# Altium schematic sheet F407_MCU.SchDoc(F407_MCU)
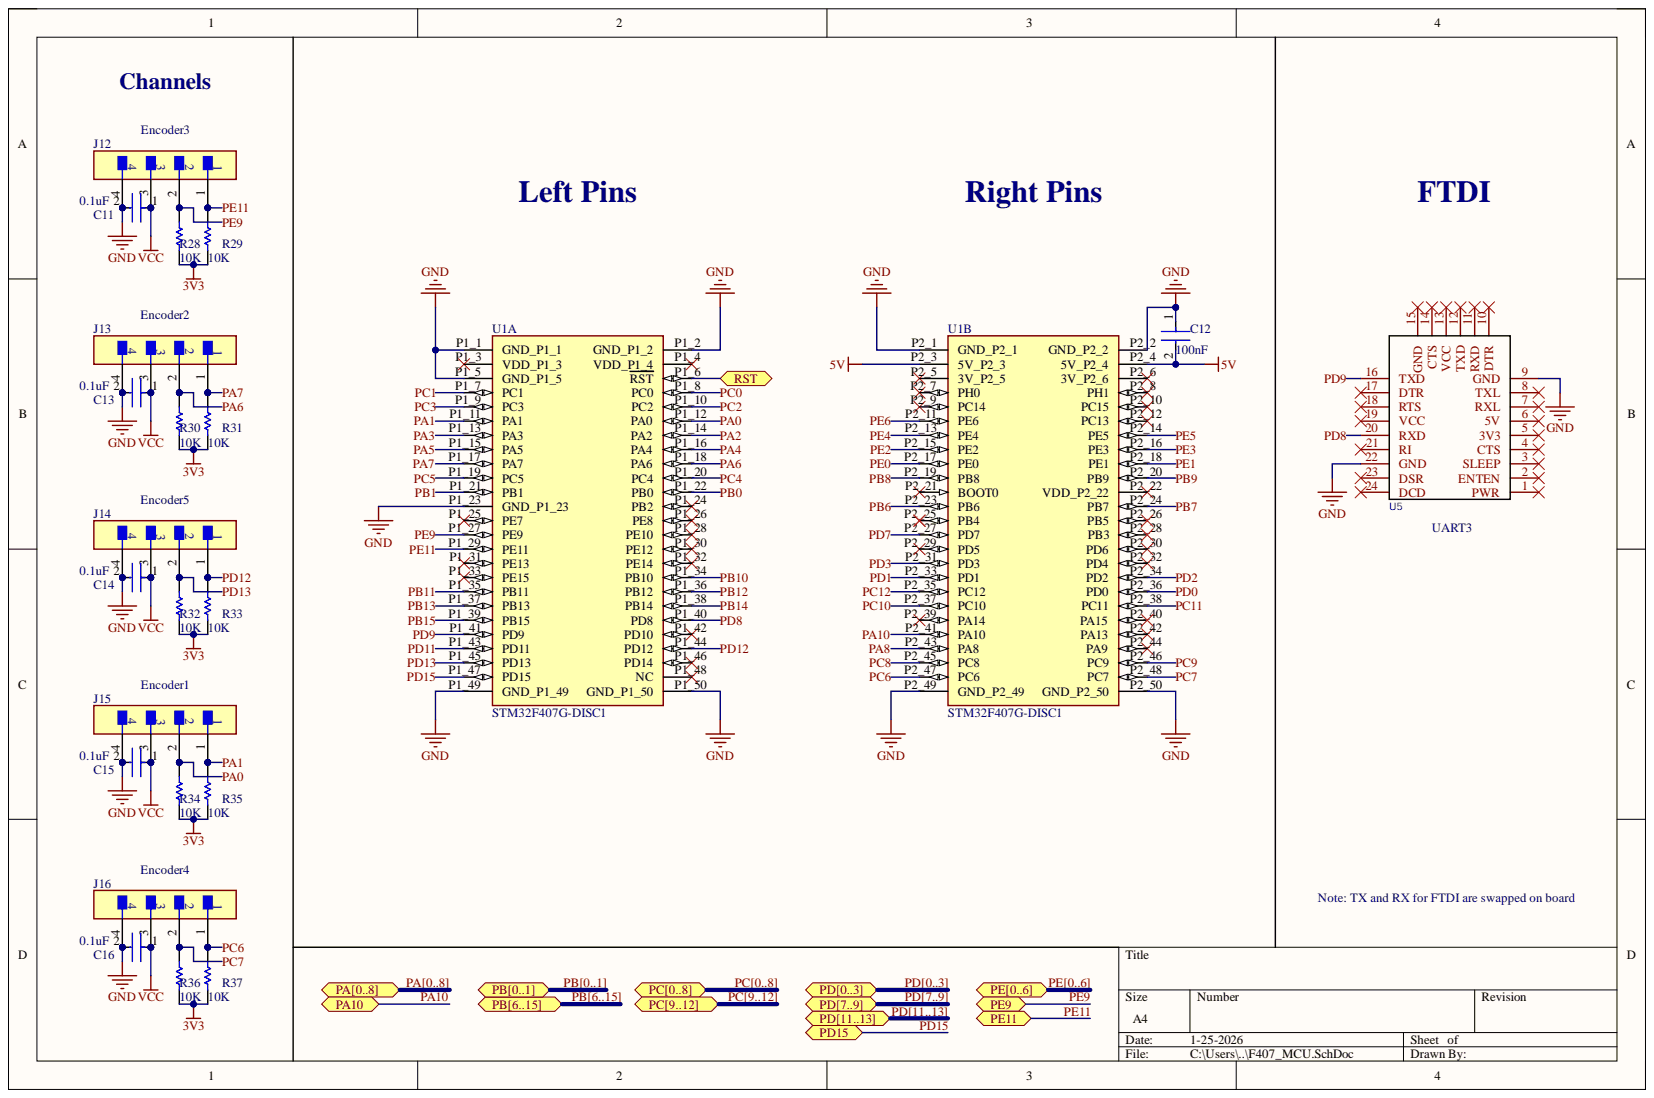
[diagram p0: F407_MCU.SchDoc]

## Parts
C11  CC0805KRX7R9BB104  [FP-CC0805-0_85-MFG]  (value not drawn)
C12  value 100 nF  [FP-CC0805-0_85-MFG]  (hidden comment: CC0805KRX7R9BB104)
C13  CC0805KRX7R9BB104  [FP-CC0805-0_85-MFG]  (value not drawn)
C14  CC0805KRX7R9BB104  [FP-CC0805-0_85-MFG]  (value not drawn)
C15  CC0805KRX7R9BB104  [FP-CC0805-0_85-MFG]  (value not drawn)
C16  CC0805KRX7R9BB104  [FP-CC0805-0_85-MFG]  (value not drawn)
J12  B4B-XH-A(LF)(SN)  [FP-B4B-XH-A_LF_SN-MFG]  (value not drawn)
J13  B4B-XH-A(LF)(SN)  [FP-B4B-XH-A_LF_SN-MFG]  (value not drawn)
J14  B4B-XH-A(LF)(SN)  [FP-B4B-XH-A_LF_SN-MFG]  (value not drawn)
J15  B4B-XH-A(LF)(SN)  [FP-B4B-XH-A_LF_SN-MFG]  (value not drawn)
J16  B4B-XH-A(LF)(SN)  [FP-B4B-XH-A_LF_SN-MFG]  (value not drawn)
R28  RC0805FR-071KL  [RESC2013X60X35ML10T20]  (value not drawn)
R29  RC0805FR-071KL  [RESC2013X60X35ML10T20]  (value not drawn)
R30  RC0805FR-071KL  [RESC2013X60X35ML10T20]  (value not drawn)
R31  RC0805FR-071KL  [RESC2013X60X35ML10T20]  (value not drawn)
R32  RC0805FR-071KL  [RESC2013X60X35ML10T20]  (value not drawn)
R33  RC0805FR-071KL  [RESC2013X60X35ML10T20]  (value not drawn)
R34  RC0805FR-071KL  [RESC2013X60X35ML10T20]  (value not drawn)
R35  RC0805FR-071KL  [RESC2013X60X35ML10T20]  (value not drawn)
R36  RC0805FR-071KL  [RESC2013X60X35ML10T20]  (value not drawn)
R37  RC0805FR-071KL  [RESC2013X60X35ML10T20]  (value not drawn)
U1  STM32F407G-DISC1  [MODULE_STM32F407G-DISC1]  (parts A,B)
U5  FT232RL FTDI USB to 3.3V/5V TTL Serial Converter Adapter Module  [FT232RL FTDI USB TO 3.3V/5V TTL SERIAL CONVERTER ADAPTER MODULE]  (value not drawn)

## Ports
PA0, PA1, PA2, PA3, PA4, PA5, PA6, PA7, PA8, PA10, PA[0..8], PB0, PB1, PB6, PB7, PB8, PB9, PB10, PB11, PB12, PB13, PB14, PB15, PB[0..1], PB[6..15], PC0, PC1, PC2, PC3, PC4, PC5, PC6, PC7, PC8, PC9, PC10, PC11, PC12, PC[0..8], PC[9..12], PD0, PD1, PD2, PD3, PD7, PD8, PD9, PD11, PD12, PD13, PD15, PD[0..3], PD[7..9], PD[11..13], PE0, PE1, PE2, PE3, PE4, PE5, PE6, PE9, PE11, PE[0..6], RST

## Nets
3V3: R28.1 R29.1 R30.1 R31.1 R32.1 R33.1 R34.1 R35.1 R36.1 R37.1
5V: C12.2 U1.P2_3(5V_P2_3) U1.P2_4(5V_P2_4)
GND: C11.2 C12.1 C13.2 C14.2 C15.2 C16.2 J12.4 J13.4 J14.4 J15.4 J16.4 U1.P1_1(GND_P1_1) U1.P1_2(GND_P1_2) U1.P1_5(GND_P1_5) U1.P1_23(GND_P1_23) U1.P1_49(GND_P1_49) U1.P1_50(GND_P1_50) U1.P2_1(GND_P2_1) U1.P2_2(GND_P2_2) U1.P2_49(GND_P2_49) U1.P2_50(GND_P2_50) U5.9(GND) U5.22(GND)
VCC: C11.1 C13.1 C14.1 C15.1 C16.1 J12.3 J13.3 J14.3 J15.3 J16.3
PA0: J15.2 R34.2 U1.P1_12(PA0) ; port PA[0..8]  [also labelled PA]
PA1: J15.1 R35.2 U1.P1_11(PA1) ; port PA[0..8]  [also labelled PA]
PA2: U1.P1_14(PA2) ; port PA[0..8]  [also labelled PA]
PA3: U1.P1_13(PA3) ; port PA[0..8]  [also labelled PA]
PA4: U1.P1_16(PA4) ; port PA[0..8]  [also labelled PA]
PA5: U1.P1_15(PA5) ; port PA[0..8]  [also labelled PA]
PA6: J13.2 R30.2 U1.P1_18(PA6) ; port PA[0..8]  [also labelled PA]
PA7: J13.1 R31.2 U1.P1_17(PA7) ; port PA[0..8]  [also labelled PA]
PA8: U1.P2_43(PA8) ; port PA[0..8]  [also labelled PA]
PA10: U1.P2_41(PA10) ; port PA10
PB0: U1.P1_22(PB0) ; port PB[0..1]  [also labelled PB]
PB1: U1.P1_21(PB1) ; port PB[0..1]  [also labelled PB]
PB6: U1.P2_23(PB6) ; port PB[6..15]  [also labelled PB]
PB7: U1.P2_24(PB7) ; port PB[6..15]  [also labelled PB]
PB8: U1.P2_19(PB8) ; port PB[6..15]  [also labelled PB]
PB9: U1.P2_20(PB9) ; port PB[6..15]  [also labelled PB]
PB10: U1.P1_34(PB10) ; port PB[6..15]  [also labelled PB]
PB11: U1.P1_35(PB11) ; port PB[6..15]  [also labelled PB]
PB12: U1.P1_36(PB12) ; port PB[6..15]  [also labelled PB]
PB13: U1.P1_37(PB13) ; port PB[6..15]  [also labelled PB]
PB14: U1.P1_38(PB14) ; port PB[6..15]  [also labelled PB]
PB15: U1.P1_39(PB15) ; port PB[6..15]  [also labelled PB]
PC0: U1.P1_8(PC0) ; port PC[0..8]  [also labelled PC]
PC1: U1.P1_7(PC1) ; port PC[0..8]  [also labelled PC]
PC2: U1.P1_10(PC2) ; port PC[0..8]  [also labelled PC]
PC3: U1.P1_9(PC3) ; port PC[0..8]  [also labelled PC]
PC4: U1.P1_20(PC4) ; port PC[0..8]  [also labelled PC]
PC5: U1.P1_19(PC5) ; port PC[0..8]  [also labelled PC]
PC6: J16.1 R37.2 U1.P2_47(PC6) ; port PC[0..8]  [also labelled PC]
PC7: J16.2 R36.2 U1.P2_48(PC7) ; port PC[0..8]  [also labelled PC]
PC8: U1.P2_45(PC8) ; port PC[0..8]  [also labelled PC]
PC9: U1.P2_46(PC9) ; port PC[9..12]  [also labelled PC]
PC10: U1.P2_37(PC10) ; port PC[9..12]  [also labelled PC]
PC11: U1.P2_38(PC11) ; port PC[9..12]  [also labelled PC]
PC12: U1.P2_35(PC12) ; port PC[9..12]  [also labelled PC]
PD0: U1.P2_36(PD0) ; port PD[0..3]  [also labelled PD]
PD1: U1.P2_33(PD1) ; port PD[0..3]  [also labelled PD]
PD2: U1.P2_34(PD2) ; port PD[0..3]  [also labelled PD]
PD3: U1.P2_31(PD3) ; port PD[0..3]  [also labelled PD]
PD7: U1.P2_27(PD7) ; port PD[7..9]  [also labelled PD]
PD8: U1.P1_40(PD8) U5.20(RXD) ; port PD[7..9]  [also labelled PD]
PD9: U1.P1_41(PD9) U5.16(TXD) ; port PD[7..9]  [also labelled PD]
PD11: U1.P1_43(PD11) ; port PD[11..13]  [also labelled PD]
PD12: J14.1 R33.2 U1.P1_44(PD12) ; port PD[11..13]  [also labelled PD]
PD13: J14.2 R32.2 U1.P1_45(PD13) ; port PD[11..13]  [also labelled PD]
PD15: U1.P1_47(PD15) ; port PD15
PE0: U1.P2_17(PE0) ; port PE[0..6]  [also labelled PE]
PE1: U1.P2_18(PE1) ; port PE[0..6]  [also labelled PE]
PE2: U1.P2_15(PE2) ; port PE[0..6]  [also labelled PE]
PE3: U1.P2_16(PE3) ; port PE[0..6]  [also labelled PE]
PE4: U1.P2_13(PE4) ; port PE[0..6]  [also labelled PE]
PE5: U1.P2_14(PE5) ; port PE[0..6]  [also labelled PE]
PE6: U1.P2_11(PE6) ; port PE[0..6]  [also labelled PE]
PE9: J12.2 R28.2 U1.P1_27(PE9) ; port PE9
PE11: J12.1 R29.2 U1.P1_29(PE11) ; port PE11
RST: U1.P1_6(RST) ; port RST
PB[0..1]: ; port PB[0..1]  [also labelled PB]
PB[6..15]: ; port PB[6..15]  [also labelled PB]
PC[0..8]: ; port PC[0..8]  [also labelled PC]
PC[9..12]: ; port PC[9..12]  [also labelled PC]
PD[0..3]: ; port PD[0..3]  [also labelled PD]
PD[7..9]: ; port PD[7..9]  [also labelled PD]
PD[11..13]: ; port PD[11..13]  [also labelled PD]

Not connected: U1 P1_3(VDD_P1_3) P1_4(VDD_P1_4) P1_24(PB2) P1_25(PE7) P1_26(PE8) P1_28(PE10) P1_30(PE12) P1_31(PE13) P1_32(PE14) P1_33(PE15) P1_42(PD10) P1_46(PD14) P1_48(NC) P2_5(3V_P2_5) P2_6(3V_P2_6) P2_7(PH0) P2_8(PH1) P2_9(PC14) P2_10(PC15) P2_12(PC13) P2_21(BOOT0) P2_22(VDD_P2_22) P2_25(PB4) P2_26(PB5) P2_28(PB3) P2_29(PD5) P2_30(PD6) P2_32(PD4) P2_39(PA14) P2_40(PA15) P2_42(PA13) P2_44(PA9); U5 1(PWR) 2(ENTEN) 3(SLEEP) 4(CTS) 5(3V3) 6(5V) 7(RXL) 8(TXL) 10(DTR) 11(RXD) 12(TXD) 13(VCC) 14(CTS) 15(GND) 17(DTR) 18(RTS) 19(VCC) 21(RI) 23(DSR) 24(DCD)

## Library data of the parts
C11-C16 = CMP-2100-03606-3: CAP CER 0.1UF 50V X7R 0805; Manufacturer: Yageo; Capacitance: 100 nF; Material: Ceramic; Tolerance: 0.1; Value: 100 nF; Automotive: No; Category: Cap; Component Kind: Standard; ComponentLink2Description: Datasheet; ComponentLink2URL: http://www.yageo.com/documents/recent/UPY-GPHC_Y5V_6.3V-to-50V_8.pdf; Device Class L1: Passive Components; Device Class L2: Capacitors; Device Class L3: Ceramic Capacitors; Digikey Description: CAP CER 0.1UF 50V X7R 0805; Footprint Url: http://www.yageo.com/exep/pages/download/literatures/UPY-C_GEN_24.pdf; Height: 0.95mm; Ipc Land Pattern Name: CAPC200125X85; Lead Free: Yes; Library Name: Altium Content Vault; Manufacturer Part Number: CC0805KRX7R9BB104; Mouser Description: Multilayer Ceramic Capacitors MLCC - SMD/SMT 100nF 50V X7R 10%; Octopart: https://octopart.com/cc0805krx7r9bb104-yageo-192993; Package: CC0805-0.85; RoHS: TRUE; Temperature: -30°C to +85°C; Temperature Characteristic: X7R; Temperature Range High: +85°C; Temperature Range Low: -30°C; Voltage Rating: 50 V
J12-J16 = CMP-17439-000250-1: CONN HEADER VERT 4POS 2.5MM; Contact Resistance: 20mR; Current Rating: 3A; Gender: Male; Insulation Resistance: 1GR; Mated Stacking Heights: 9.8mm; Max Voltage Rating (AC): 250V; Number of Contacts: 4; Wire/Cable Gauge: 22AWG; Color: Natural; Component Kind: Standard; Connector Type: Header; Contact Finish - Mating: TIN; Contact Material: Brass; Contact Plating: Tin; Contact Shape: Square; Contact Type: Male Pin; Depth: 5.75mm; Fastening Type: Detent; Flammability Rating: UL94 V-0; Housing Color: White; Housing Material: Polyamide; Insulation Color: Natural; Insulation Material: Nylon; Lead Free: Lead Free; Lead Pitch: 2.5mm; Length: 12.4mm; Library Name: Altium Content Vault; Material: Polyamide; Max Current Rating: 3A; Max Operating Temperature: 85°C; Max Voltage Rating (DC): 250V; Min Operating Temperature: -25°C; Mount: Through Hole; Number of Positions: 4; Number of Rows: 1; Orientation: Straight; Package Quantity: 500; Packaging: Bulk; Pitch: 2.5mm; REACH SVHC: No SVHC; Series: XH; Termination: Solder; Voltage Rating: 250V; Voltage Rating (AC): 250V
R28-R37 = CMP-2100-03671-1: Chip Resistor, 1 KOhm, +/- 1%, 125 mW, -55 to 155 degC, 0805 (2012 Metric), RoHS, Tape and Reel; Manufacturer: Yageo; Max Power Dissipation: 125mW; Resistance: 1KOhm; Temperature Coefficient: 100ppm/degreesC; Voltage Rating: 150V; Case Code (Imperial): 0805; Case Code (Metric): 2012; Case/Package: 0805; Component Kind: Standard; Composition: ThickFilm; Datasheet URL: http://www.yageo.com/NewPortal/yageodocoutput?fileName=/pdf/R-Chip/PYu-RC_Group_51_RoHS_L_5.pdf; Datasheet Version: Rev. 5, 10/2016; Depth: 1.25mm; Height: 0.024inch; Lead Free: LeadFree; Length: 0.079inch; Library Name: Altium Content Vault; Manufacturer URL: http://www.yageo.com/; Max Operating Temperature: 155degC; Military Standard: Not; Min Operating Temperature: -55degC; Number of Pins: 2; Number of Terminations: 2; Package Description: 2-Pin Surface Mounted Device, Body 2 x 1.25 mm; Package Quantity: 1; Package Reference: 0805; Packaging: TapeandReel; Power Rating: 125mW; REACH SVHC: Unknown; RoHSCompliant: true; Termination: SMD/SMT; Tolerance: 1%; Voltage Rating (DC): 150V; Width: 0.049inch
U1 = STM32F407G-DISC1: STM32F407, STM32F417 Discovery STM32F4 ARMÂ® CortexÂ®-M4 MCU 32-Bit Embedded Evaluation Board; MF: STMicroelectronics; Check_prices: https://www.snapeda.com/parts/STM32F407G-DISC1/STMicroelectronics/view-part/?ref=eda; Component Kind: Standard; Library Name: STM32F407G-DISC1.SchLib; MP: STM32F407G-DISC1; Package: None; SnapEDA_Link: https://www.snapeda.com/parts/STM32F407G-DISC1/STMicroelectronics/view-part/?ref=snap
U5 = FT232RL FTDI USB to 3.3V/5V TTL Serial Converter Adapter Module: Component Kind: Standard; Library Name: FT232RL-FTDI-USB-to-3.3V-5V-TTL-Serial-Converter-Adapter-Module_2025-04-18.SCHLIB
